# Altium schematic sheet usd.SchDoc
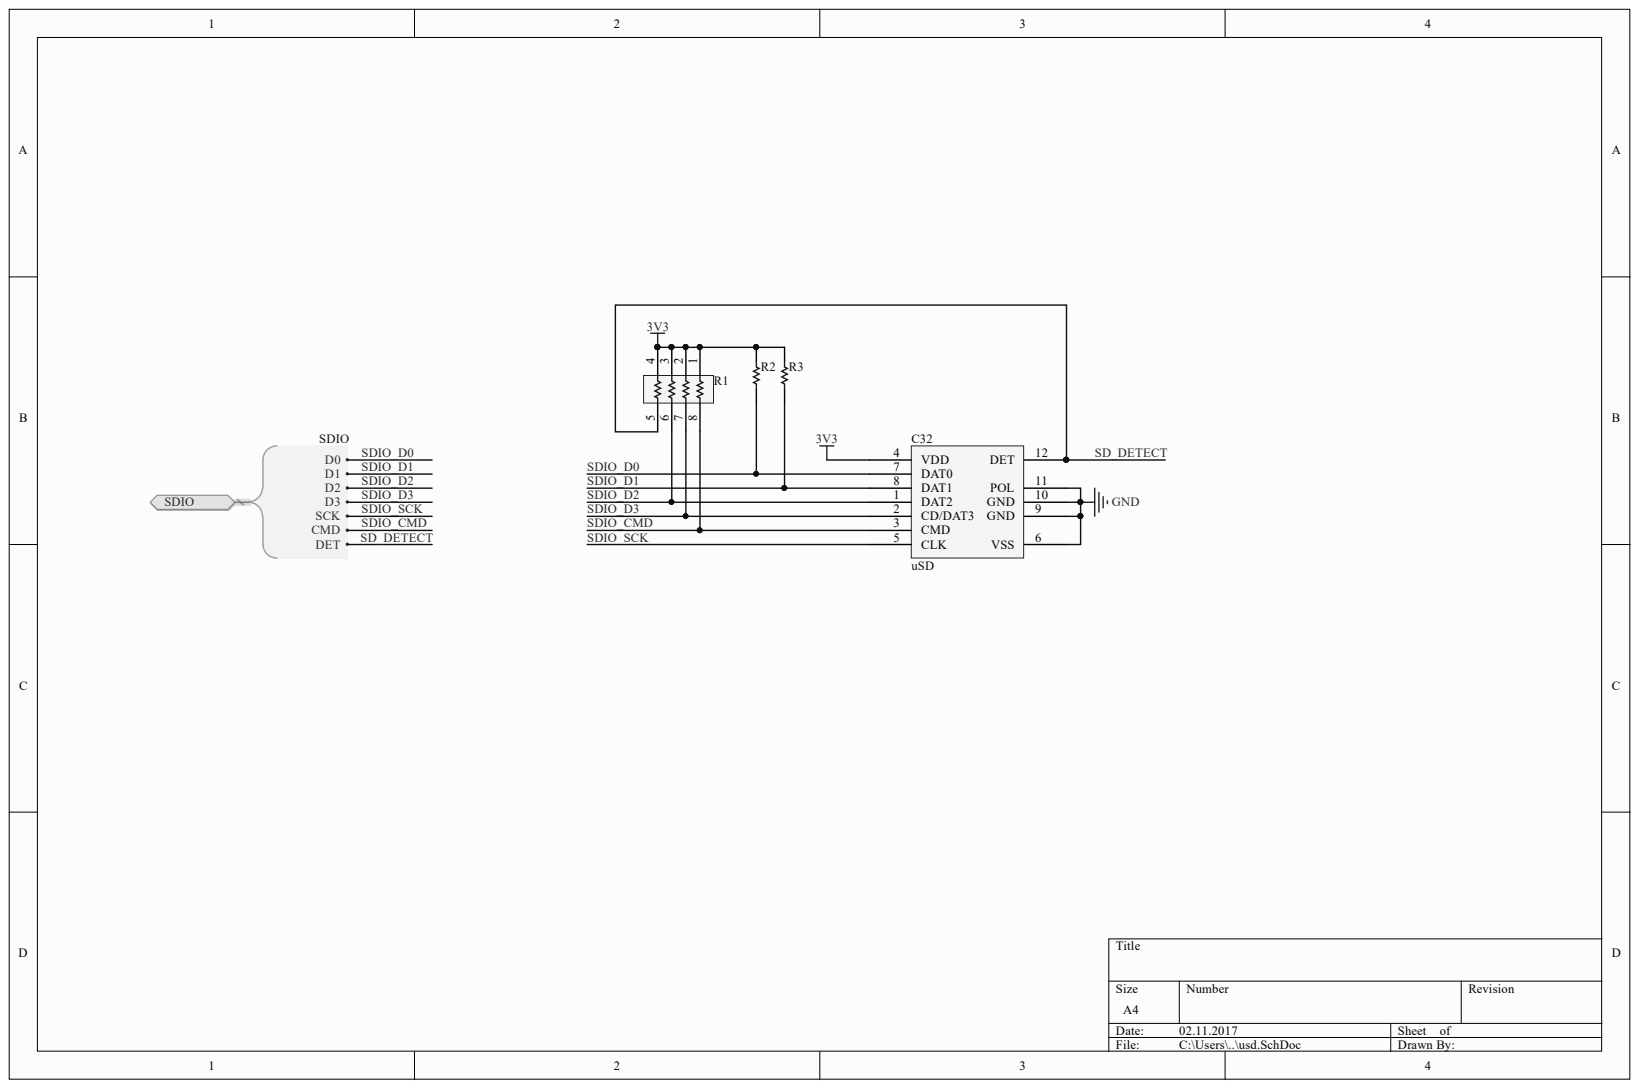
[diagram p0: usd.SchDoc]

## Parts
C32  uSD  [47309-XXX 3.35mm]
R1  CAY16-103J4LF  [BOUR-CAY16-J4_V]  (value not drawn)
R2  47K 5% 0402(1005)  [RESC0402(1005)_M]  (value not drawn)
R3  47K 5% 0402(1005)  [RESC0402(1005)_M]  (value not drawn)

## Ports
SDIO, SDIO.CMD, SDIO.D0, SDIO.D1, SDIO.D2, SDIO.D3, SDIO.DET, SDIO.SCK

## Nets
3V3: C32.4(VDD) R1.1 R1.2 R1.3 R1.4 R2.2 R3.2
GND: C32.6(VSS) C32.9(GND) C32.10(GND) C32.11(POL)
SD_DETECT: C32.12(DET) R1.5 ; port SDIO
SDIO_CMD: C32.3(CMD) R1.8 ; port SDIO
SDIO_D0: C32.7(DAT0) R2.1 ; port SDIO
SDIO_D1: C32.8(DAT1) R3.1 ; port SDIO
SDIO_D2: C32.1(DAT2) R1.6 ; port SDIO
SDIO_D3: C32.2(CD/DAT3) R1.7 ; port SDIO
SDIO_SCK: C32.5(CLK) ; port SDIO

## Library data of the parts
C32 = 47309-XXX: molex MICROSD/MICROSIM Connector; Component Kind: Standard; Library Name: molex.SchLib
R1 = CMP-1747-00005-1: Chip Resistor Array, 10 KOhm, +/- 5%, 62.5 mW, -55 to 125 degC, 8-Pin SMD, RoHS, Tape and Reel; Case\Package: 0603; Power Rating: 100 mW; Resistance: 220 Ohm; Tolerance: 1%; Voltage Rating: 75 V; Case Code (Imperial): 0603; Case Code (Metric): 1608; Component Kind: Standard; ComponentLink1Description: Manufacturer URL; ComponentLink1URL: http://www.bourns.com/; ComponentLink2Description: Datasheet; ComponentLink2URL: http://www.bourns.com/docs/Product-Datasheets/CATCAY.pdf; DatasheetVersion: Jan-15; Height: 0.022 inch; Length: 0.063 inch; Library Name: Altium Content Vault; Max Operating Temperature: 155 degC; Min Operating Temperature: -55 degC; Mount: Surface Mount; PackageDescription: 8-Pin Surface Mount Device, Body 1.6 x 3.2 mm, Pitch 0.8 mm; PackageReference: CAY16-J4; Packaging: Tape and Reel; Pins: 2; Radiation Hardening: No; REACH SVHC: Yes; RoHSCompliant: true; Weight: 7.1E-05 oz; Width: 0.031 inch
R2 R3 = CMP-1011-00114-1: 47K 0.063W 5% 0402 (1005 Metric)  SMD; Case-EIA: 0402; Case-Metric: 1005; Value: 47000.00 Ohm; Component Kind: Standard; Library Name: Altium Content Vault; Power: 0.063 W; Technology: SMT; Tolerance: 5 %
